# Altium schematic sheet optoIsolatorBoard.SchDoc
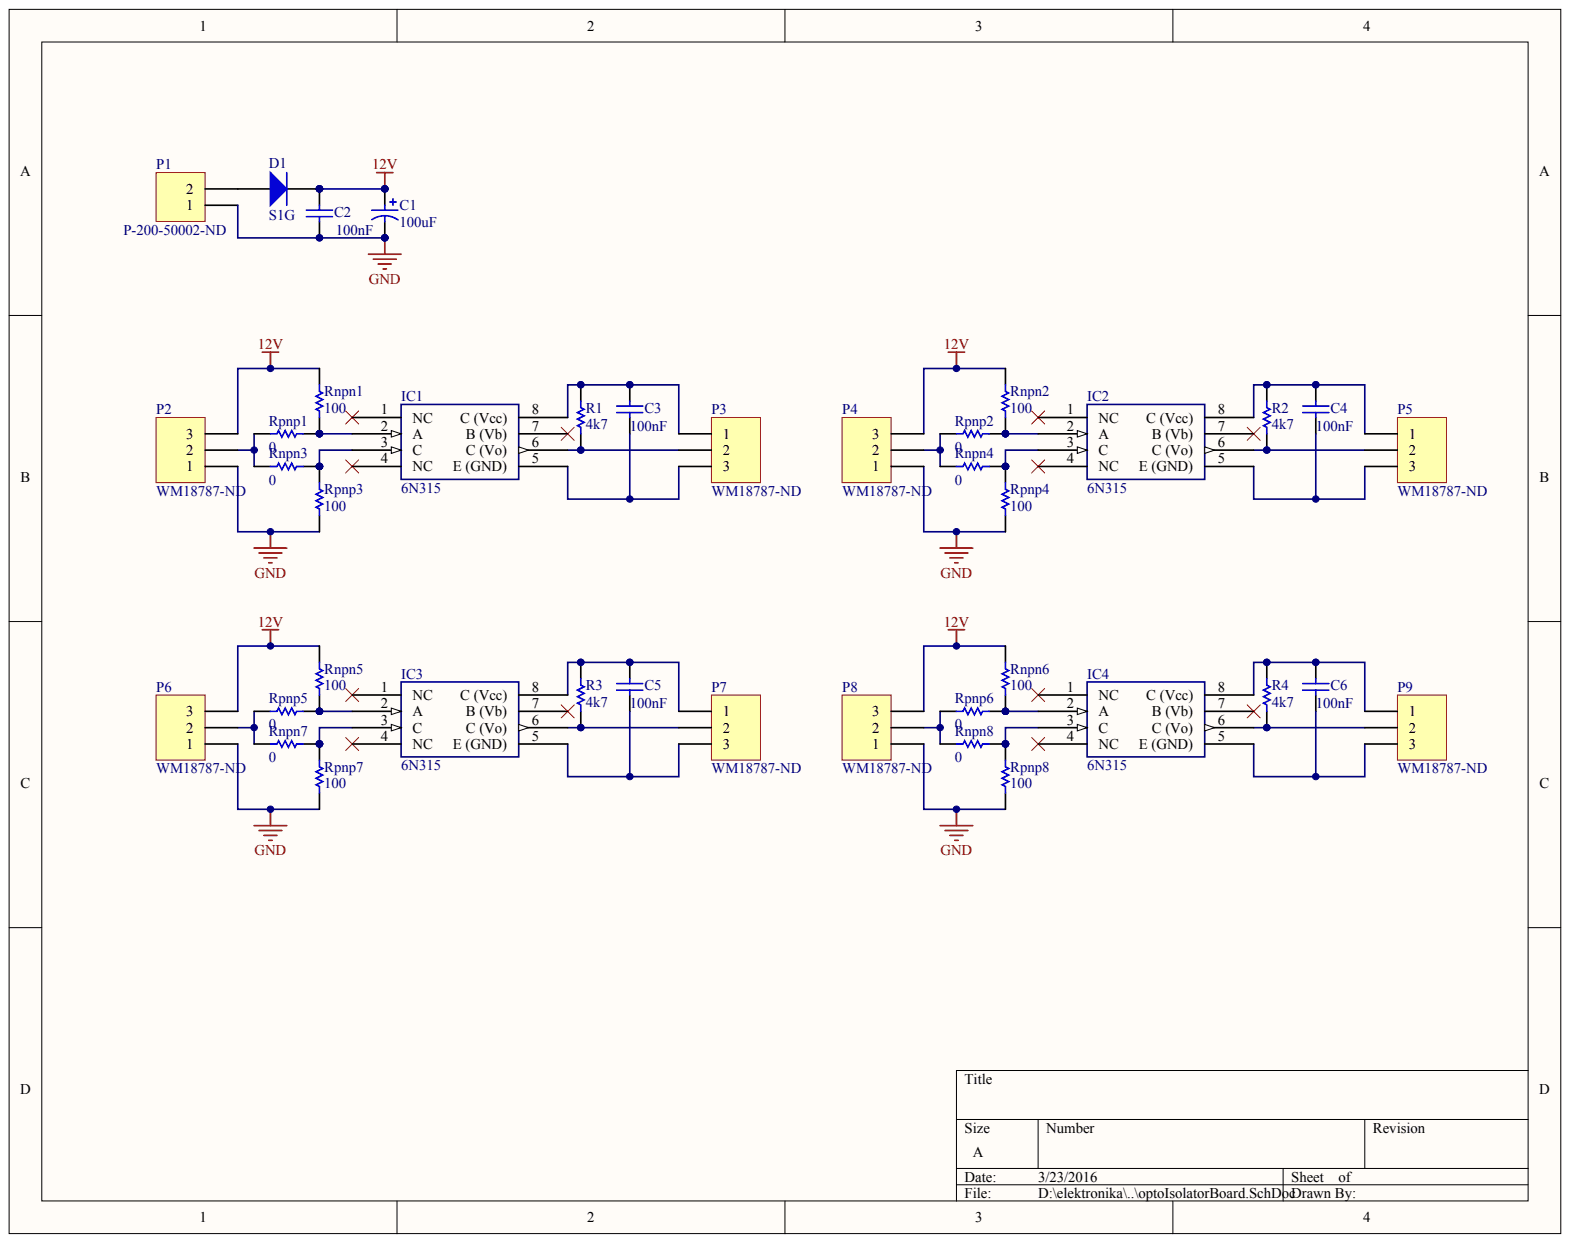
[diagram p0: optoIsolatorBoard.SchDoc]

## Parts
C1  100uF
C2  100nF
C3  value 100nF  (hidden comment: cap_1206)
C4  value 100nF  (hidden comment: cap_1206)
C5  value 100nF  (hidden comment: cap_1206)
C6  value 100nF  (hidden comment: cap_1206)
D1  S1G
IC1  6N315
IC2  6N315
IC3  6N315
IC4  6N315
P1  P-200-50002-ND
P2  WM18787-ND
P3  WM18787-ND
P4  WM18787-ND
P5  WM18787-ND
P6  WM18787-ND
P7  WM18787-ND
P8  WM18787-ND
P9  WM18787-ND
R1  4k7
R2  4k7
R3  4k7
R4  4k7
Rnpn1  100
Rnpn2  100
Rnpn3  0
Rnpn4  0
Rnpn5  100
Rnpn6  100
Rnpn7  0
Rnpn8  0
Rpnp1  0  (value not drawn)
Rpnp2  0
Rpnp3  100
Rpnp4  100
Rpnp5  0  (value not drawn)
Rpnp6  0
Rpnp7  100
Rpnp8  100

## Nets
12V: C1.1 C2.2 D1.2 P2.3 P4.3 P6.3 P8.3 Rnpn1.1 Rnpn2.1 Rnpn5.1 Rnpn6.1
GND: C1.2 C2.1 P1.1 P2.1 P4.1 P6.1 P8.1 Rpnp3.2 Rpnp4.2 Rpnp7.2 Rpnp8.2
NetC3_1: C3.1 IC1.5 P3.3
NetC3_2: C3.2 IC1.8(C_(Vcc)) P3.1 R1.2
NetC4_1: C4.1 IC2.5 P5.3
NetC4_2: C4.2 IC2.8(C_(Vcc)) P5.1 R2.2
NetC5_1: C5.1 IC3.5 P7.3
NetC5_2: C5.2 IC3.8(C_(Vcc)) P7.1 R3.2
NetC6_1: C6.1 IC4.5 P9.3
NetC6_2: C6.2 IC4.8(C_(Vcc)) P9.1 R4.2
NetD1_1: D1.1 P1.2
NetIC1_2: IC1.2(A) Rnpn1.2 Rpnp1.1
NetIC1_3: IC1.3(C) Rnpn3.1 Rpnp3.1
NetIC1_6: IC1.6(C_(Vo)) P3.2 R1.1
NetIC2_2: IC2.2(A) Rnpn2.2 Rpnp2.1
NetIC2_3: IC2.3(C) Rnpn4.1 Rpnp4.1
NetIC2_6: IC2.6(C_(Vo)) P5.2 R2.1
NetIC3_2: IC3.2(A) Rnpn5.2 Rpnp5.1
NetIC3_3: IC3.3(C) Rnpn7.1 Rpnp7.1
NetIC3_6: IC3.6(C_(Vo)) P7.2 R3.1
NetIC4_2: IC4.2(A) Rnpn6.2 Rpnp6.1
NetIC4_3: IC4.3(C) Rnpn8.1 Rpnp8.1
NetIC4_6: IC4.6(C_(Vo)) P9.2 R4.1
NetP2_2: P2.2 Rnpn3.2 Rpnp1.2
NetP4_2: P4.2 Rnpn4.2 Rpnp2.2
NetP6_2: P6.2 Rnpn7.2 Rpnp5.2
NetP8_2: P8.2 Rnpn8.2 Rpnp6.2

Not connected: IC1 1(NC) 4(NC) 7(B_(Vb)); IC2 1(NC) 4(NC) 7(B_(Vb)); IC3 1(NC) 4(NC) 7(B_(Vb)); IC4 1(NC) 4(NC) 7(B_(Vb))

## Library data of the parts
C1-C6 D1 IC1-IC4 P1-P9 R1-R4 Rnpn1-Rnpn8 Rpnp1-Rpnp8 = (no symbol name)
  C1: Value: 100uF; Supplier 1: Farnell; Supplier Part Number 1: 9451188; Supplier 2: Mouser; Supplier Part Number 2: 667-ECA-1EHG101
  C2: Published: 8-Jun-2000; LatestRevisionDate: 17-Jul-2002; LatestRevisionNote: Re-released for DXP Platform.; PackageReference: RAD-0.3; Value: 100nF; Supplier 1: Mouser; Supplier Part Number 1: 581-12065C104K; Supplier 2: Mouser; Supplier Part Number 2: 80-C1206C104K5R
  C3-C6: Published: 8-Jun-2000; LatestRevisionDate: 17-Jul-2002; LatestRevisionNote: Re-released for DXP Platform.; PackageReference: RAD-0.3
  D1: Published: 8-Jun-2000; LatestRevisionDate: 17-Jul-2002; LatestRevisionNote: Re-released for DXP Platform.; DatasheetDocument: 1997; PackageReference: DO-35; Code_JEDEC: DO-204-AH; ComponentLink1Description: Manufacturer Link; ComponentLink1URL: http://www.fairchildsemi.com/; ComponentLink2Description: Datasheet; ComponentLink2URL: http://www.fairchildsemi.com/ds/1N%2F1N4148.pdf; Supplier 1: Mouser; Supplier Part Number 1: 512-S1G; Supplier 2: Digi-Key; Supplier Part Number 2: S1GFSCT-ND
  IC1-IC4: Value: 6N315; Supplier 1: Digi-Key; Supplier Part Number 1: 160-1785-ND; Supplier 2: Mouser; Supplier Part Number 2: 859-6N135S; Supplier 3: Digi-Key; Supplier Part Number 3: 6N135-ND
  P1: LatestRevisionDate: 17-Jul-2002; LatestRevisionNote: Re-released for DXP Platform.; Value: P-200-50002-ND; Supplier 1: Digi-Key; Supplier Part Number 1: P-200-50002-ND
  P2-P9: LatestRevisionDate: 17-Jul-2002; LatestRevisionNote: Re-released for DXP Platform.; Value: WM18787-ND; Supplier 1: Digi-Key; Supplier Part Number 1: WM18787-ND; Supplier 2: Mouser; Supplier Part Number 2: 538-22-04-1041
  R1: Published: 8-Jun-2000; LatestRevisionDate: 17-Jul-2002; LatestRevisionNote: Re-released for DXP Platform.; PackageReference: AXIAL-0.3; Value: 4k7; Supplier 1: Digi-Key; Supplier Part Number 1: 311-4.7KERDKR-ND; Supplier 2: Mouser; Supplier Part Number 2: 71-CRCW1206-4.7K-E3
  R2-R4: Published: 8-Jun-2000; LatestRevisionDate: 17-Jul-2002; LatestRevisionNote: Re-released for DXP Platform.; PackageReference: AXIAL-0.3; Value: 4k7
  Rnpn1 Rnpn2 Rnpn5 Rnpn6 Rpnp3 Rpnp4 Rpnp7 Rpnp8: Published: 8-Jun-2000; LatestRevisionDate: 17-Jul-2002; LatestRevisionNote: Re-released for DXP Platform.; PackageReference: AXIAL-0.3; Value: 100; Supplier 1: Digi-Key; Supplier Part Number 1: 311-100FRCT-ND; Supplier 2: Mouser; Supplier Part Number 2: 71-CRCW1206-100-E3
  Rnpn3 Rnpn4 Rnpn7 Rnpn8 Rpnp1 Rpnp2 Rpnp5 Rpnp6: Published: 8-Jun-2000; LatestRevisionDate: 17-Jul-2002; LatestRevisionNote: Re-released for DXP Platform.; PackageReference: AXIAL-0.3; Value: 0; Supplier 1: Mouser; Supplier Part Number 1: 71-CRCW1206-0-E3; Supplier 2: Digi-Key; Supplier Part Number 2: P0.0ECT-ND
  C2-C6 D1 P1-P9 R1-R4 Rnpn1-Rnpn8 Rpnp1-Rpnp8: Publisher: Altium Limited
